# Altium schematic sheet LTM8074_PA.SchDoc
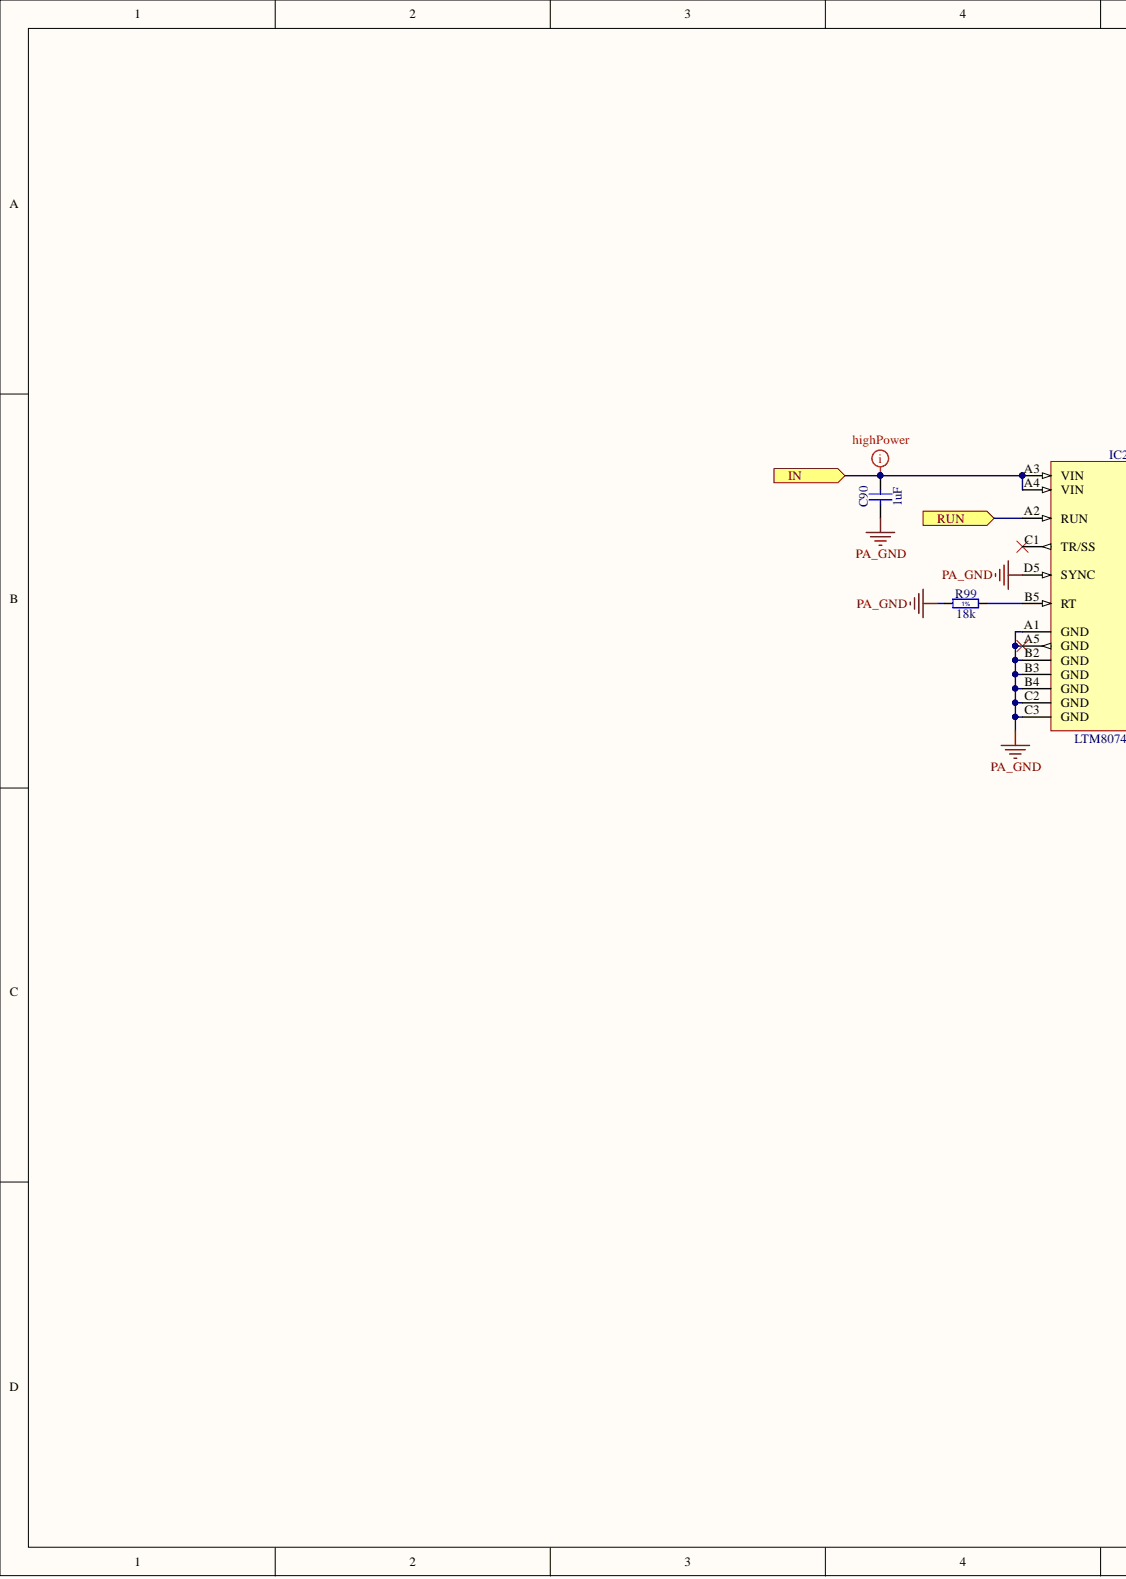
[diagram p0: LTM8074_PA.SchDoc, left]
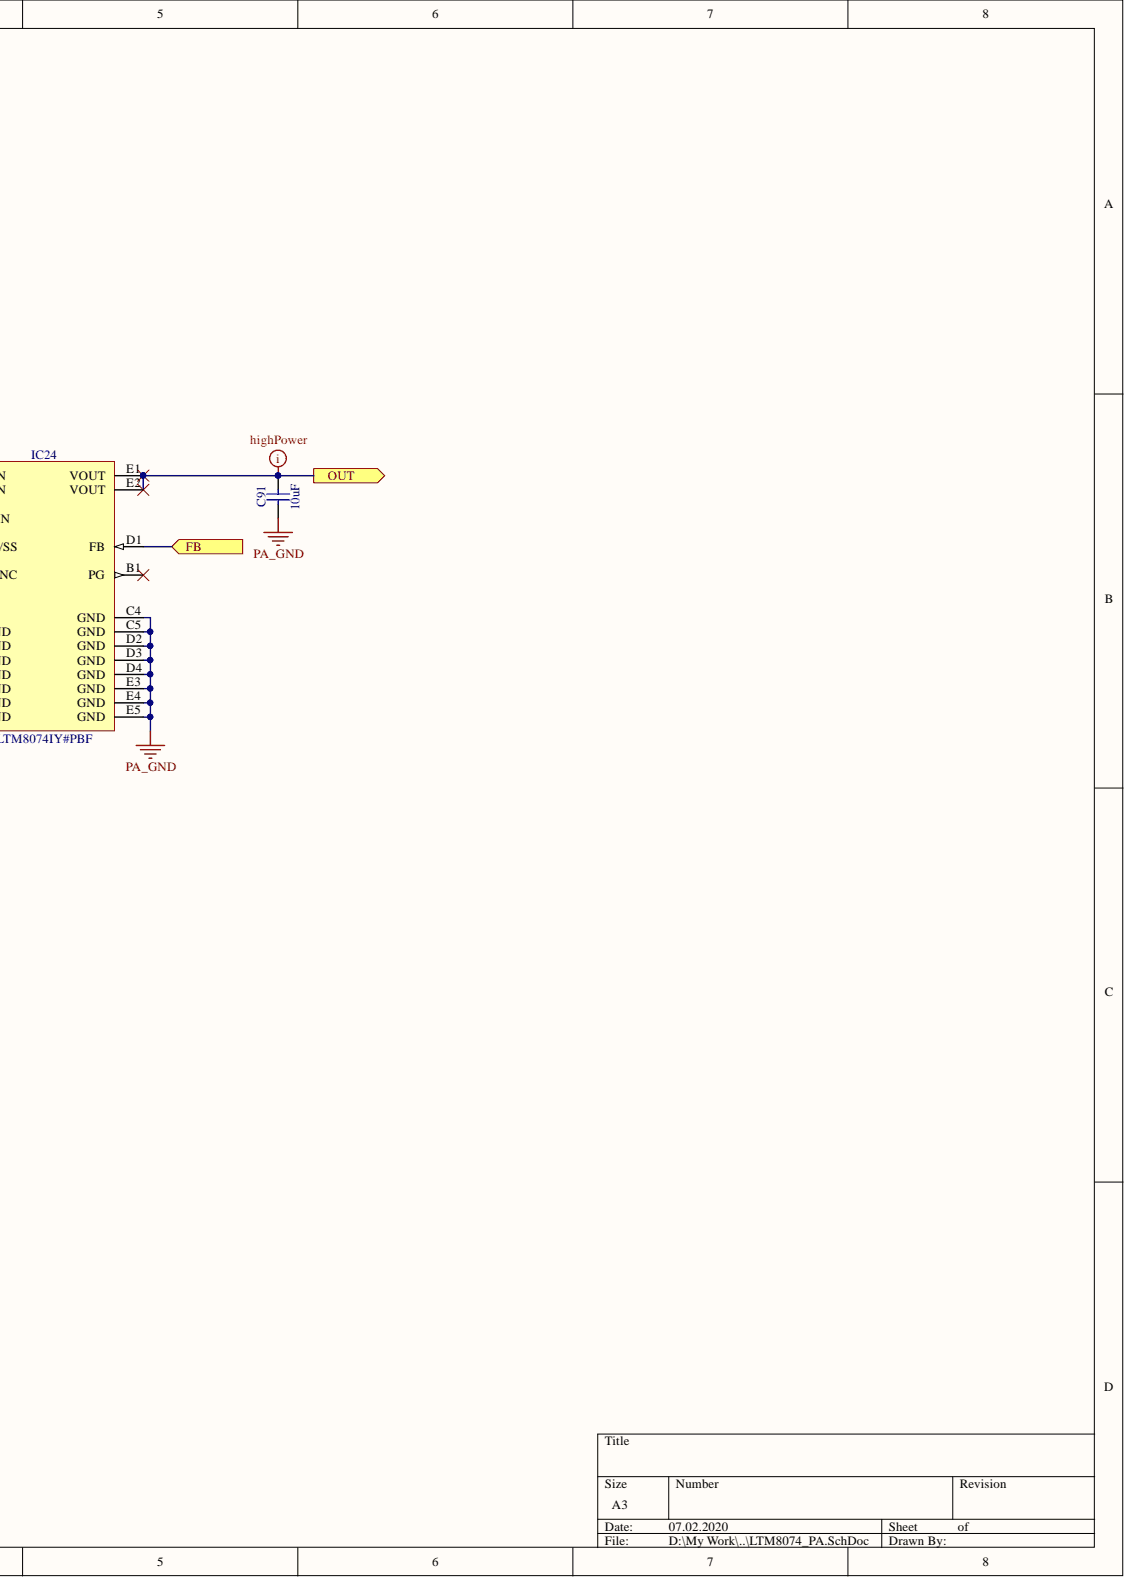
[diagram p1: LTM8074_PA.SchDoc, right]

## Parts
C90  1uF  [CAPC2012X110N]
C91  10uF  [CAPC2012X95N]
IC24  LTM8074IY#PBF  [BGA25C65P5X5_400X400X198]
R99  18k  [RESC1608X55N]

## Ports
FB, IN, OUT, RUN

## Nets
PA_GND: C90.1 C91.1 IC24.A1(GND) IC24.A5(GND) IC24.B2(GND) IC24.B3(GND) IC24.B4(GND) IC24.C2(GND) IC24.C3(GND) IC24.C4(GND) IC24.C5(GND) IC24.D2(GND) IC24.D3(GND) IC24.D4(GND) IC24.D5(SYNC) IC24.E3(GND) IC24.E4(GND) IC24.E5(GND) R99.1
FB: IC24.D1(FB) ; port FB
IN: C90.2 IC24.A3(VIN) IC24.A4(VIN) ; port IN
OUT: C91.2 IC24.E1(VOUT) IC24.E2(VOUT) ; port OUT
RUN: IC24.A2(RUN) ; port RUN
NetIC24_B5: IC24.B5(RT) R99.2

Not connected: IC24 B1(PG) C1(TR/SS)

## Library data of the parts
C90 = CC0805_1UF_50V_10%_X7R: Non-polarised capacitor; Manufacturer: GENERIC; Manufacturer1 Part Number: C0805C105K5RACTU; Part Description: SMD Multilayer Chip Ceramic Capacitor; Database Table Name: Capacitors SMD; TC: X7R; Tolerance: ±10%; Voltage: 50V; Case: 0805; Component Kind: Standard; Component Type: Standard; CreateDate: 04.12.2013; HelpURL: \\cern.ch\dfs\Applications\Altium\Datasheets\CC0805_X7R_KEMET.pdf; LatestRevisionDate: 04.12.2013; Library Name: Capacitors.DbLib; Manufacturer1 ComponentHeight: 1.1mm; Manufacturer1 Example: KEMET; Manufacturer Part Number: CC0805_1UF_50V_10%_X7R; Mounted: Yes; Part Number: CC0805_1UF_50V_10%_X7R; Pin Count: 2; Sense: No; SMD: Yes; Socket: No; Status: Preferred; Value: 1uF; Author: CERN DEM JLC
C91 = CC0805_10UF_25V_10%_X5R: Non-polarised capacitor; Manufacturer: GENERIC; Manufacturer1 Part Number: GRM219R61E106KA12D; Part Description: SMD Multilayer Chip Ceramic Capacitor; Database Table Name: Capacitors SMD; TC: X5R; Tolerance: ±10%; Voltage: 25V; Case: 0805; Component Kind: Standard; Component Type: Standard; CreateDate: 25.10.2012; HelpURL: \\cern.ch\dfs\Applications\Altium\Datasheets\CC0805_X5R_MURATA_GRM.pdf; LatestRevisionDate: 06.09.2016; Library Name: Capacitors.DbLib; Manufacturer1 ComponentHeight: 0.95mm; Manufacturer1 Example: MURATA; Manufacturer Part Number: CC0805_10UF_25V_10%_X5R; Mounted: Yes; Part Number: CC0805_10UF_25V_10%_X5R; Pin Count: 2; Sense: No; SMD: Yes; Socket: No; Status: Preferred; Value: 10uF; Author: CERN DEM JLC
IC24 = LTM8074IY#PBF: 40VIN, 1.2A Silent Switcher µModule Regulator; Manufacturer: LINEAR TECHNOLOGY; Bonding: No; Database Table Name: Regulators; PressFit: No; Case: BGA25; ComponentHeight: 1.98mm; Component Kind: Standard; Component Type: Standard; CreateDate: 08.04.2019; Device: LTM8074IY#PBF; HelpURL: \\cern.ch\dfs\Applications\Altium\Datasheets\LTM8074IY#PBF.pdf; LatestRevisionDate: 08.04.2019; Library Name: ICs And Semiconductors.DbLib; Manufacturer Part Number: LTM8074IY#PBF; Mounted: Yes; PackageDescription: BGA, 0.65mm Pitch, Square; 25 Pin, 5 Columns X 5 Rows, 4.00mm X 4.00mm X 1.98mm H; Part Description: 40VIN, 1.2A Silent Switcher µModule Regulator; Part Number: LTM8074IY#PBF; Pin Count: 25; Sense: No; SMD: Yes; Socket: No; Author: CERN DEM JLC
R99 = R0603_18K_1%_0.1W_100PPM: Resistor - 1%; Manufacturer: GENERIC; Manufacturer1 Part Number: NRC06F1802TRF; Part Description: General Purpose Thick Film Chip Resistor; Database Table Name: Resistors SMD; Power: 0.1W; PressFit: No; TC: ±100ppm/°C; Case: 0603; Component Kind: Standard; Component Type: Standard; CreateDate: 03.12.2007; HelpURL: \\cern.ch\dfs\Applications\Altium\Datasheets\R0603_NIC_NRC.pdf; LatestRevisionDate: 13.03.2008; Library Name: Resistors.DbLib; Manufacturer1 ComponentHeight: 0.55mm; Manufacturer1 Example: NIC COMPONENT; Manufacturer Part Number: R0603_18K_1%_0.1W_100PPM; Mounted: Yes; Part Number: R0603_18K_1%_0.1W_100PPM; Pin Count: 2; Sense: No; SMD: Yes; Socket: No; Status: Preferred; Tolerance: ±1%; Value: 18k; Author: CERN DEM JLC
